# Altium schematic sheet Main_BLE.SchDoc
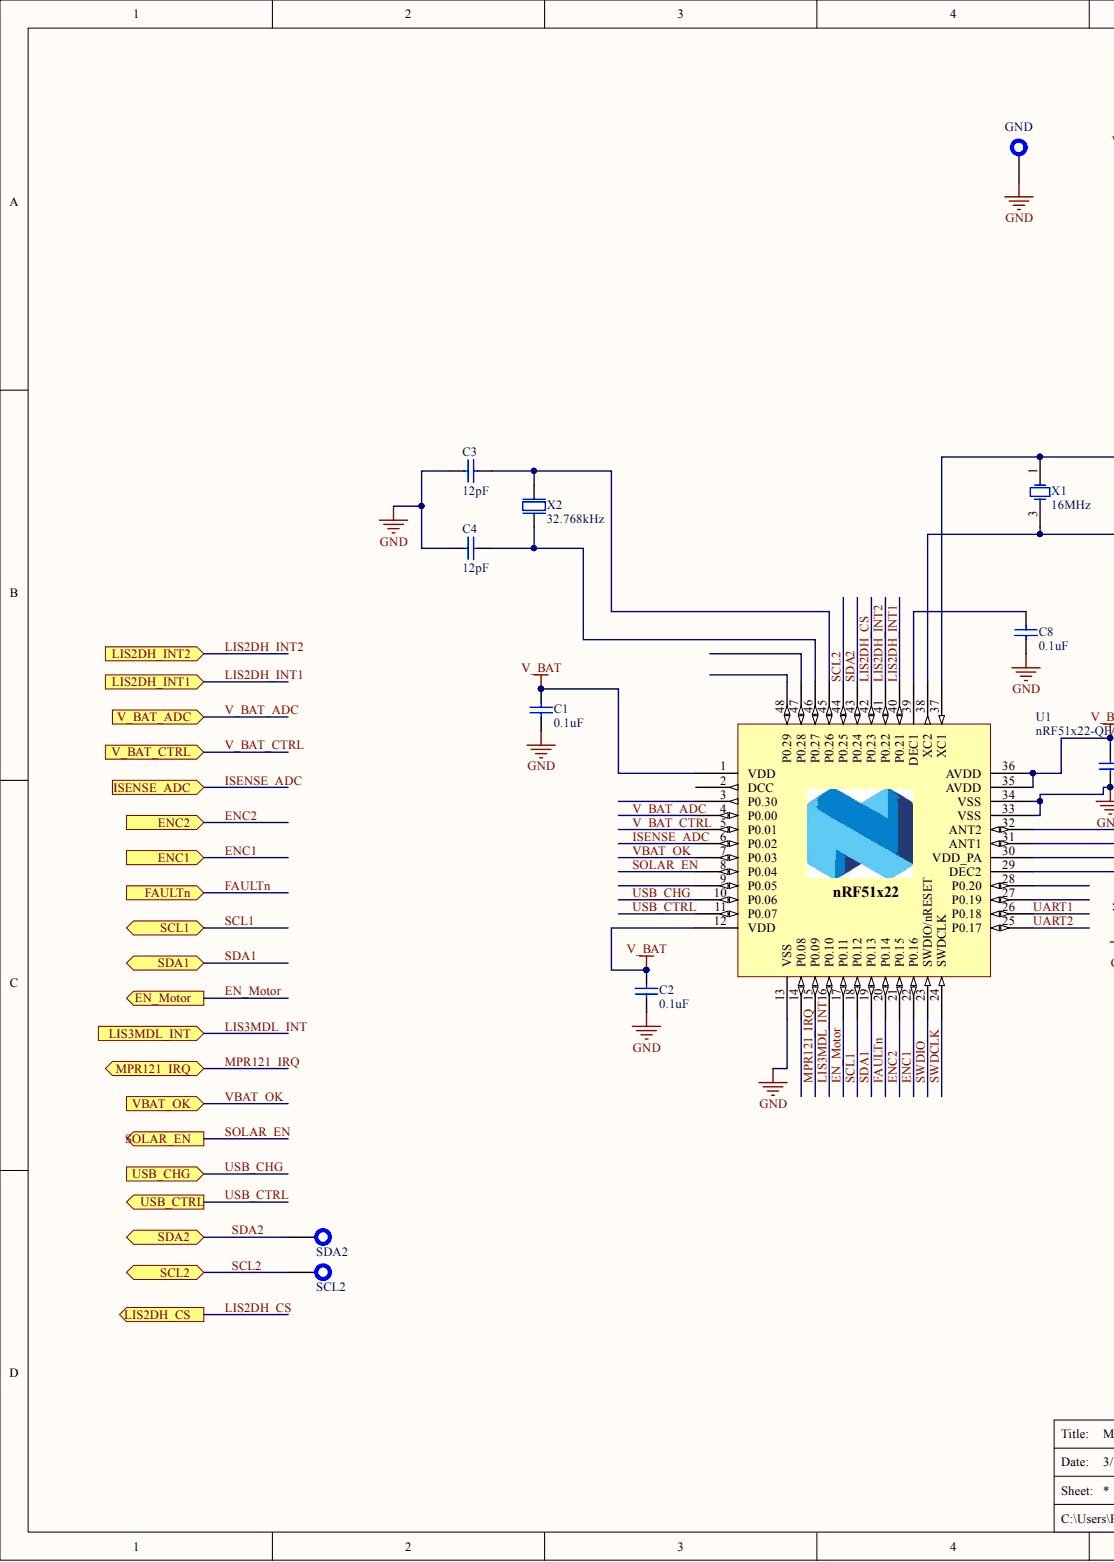
[diagram p0: Main_BLE.SchDoc, left]
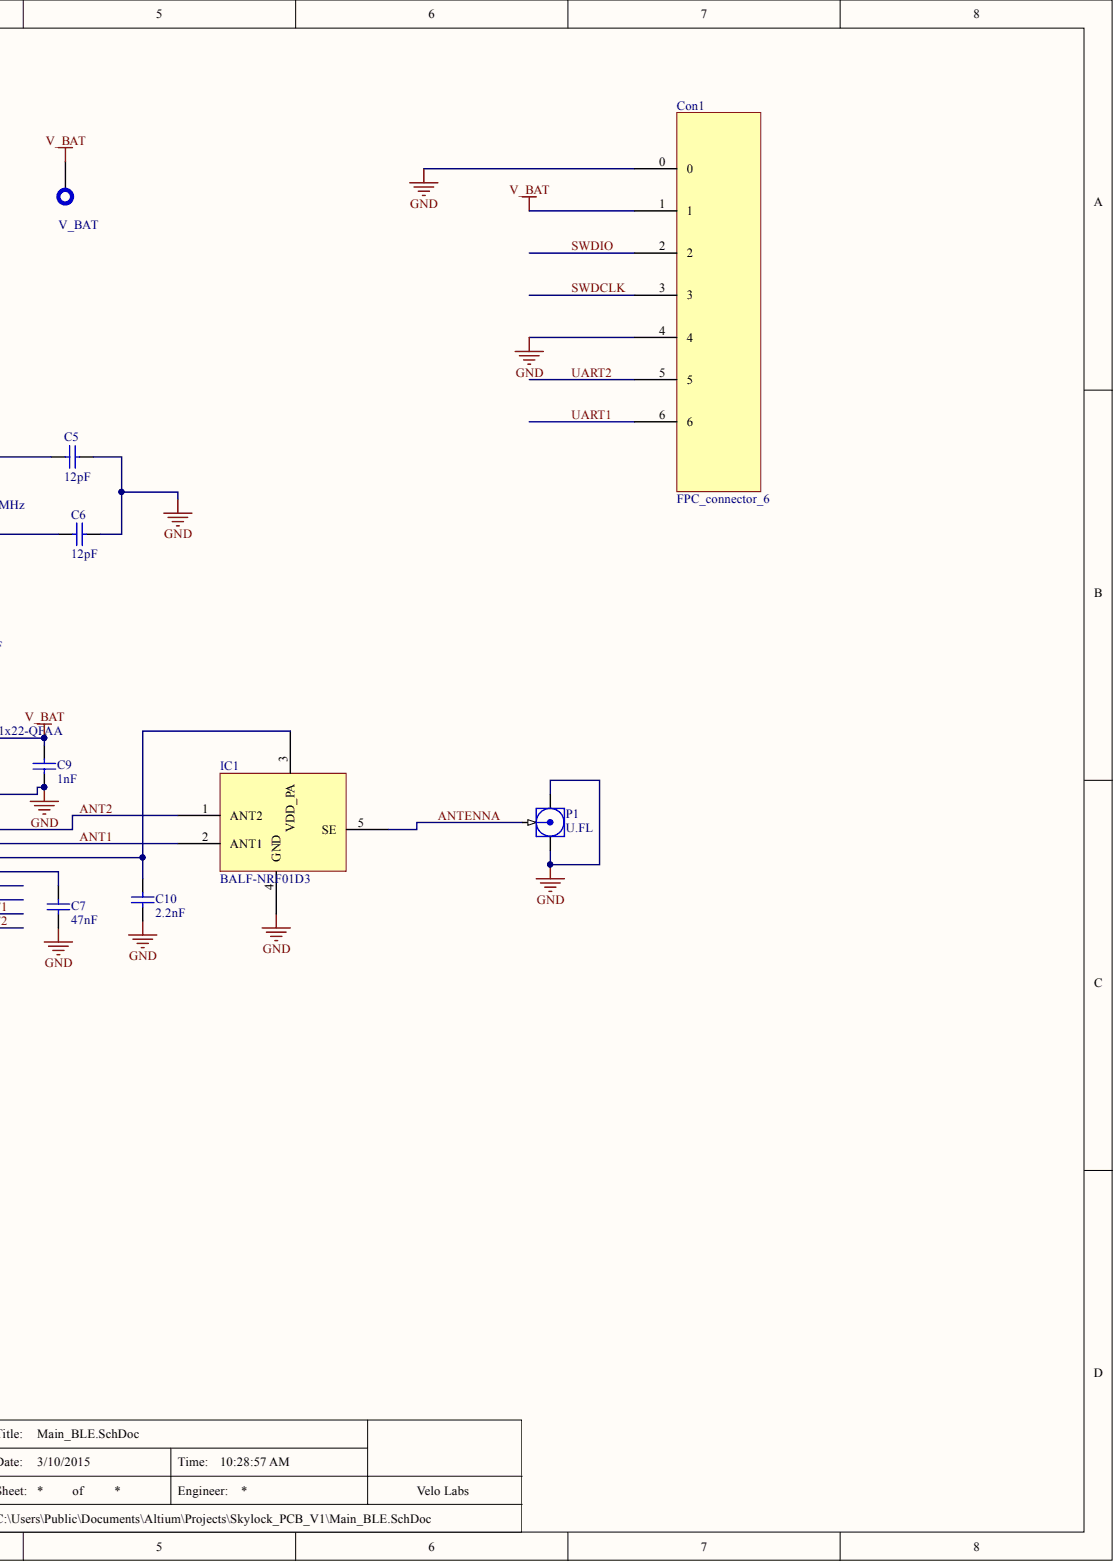
[diagram p1: Main_BLE.SchDoc, right]

## Parts
C1  0.1uF  [C0402]
C2  0.1uF  [C0402]
C3  12pF  [C0402]
C4  12pF  [C0402]
C5  12pF  [C0402]
C6  12pF  [C0402]
C7  47nF  [C0402]
C8  0.1uF  [C0402]
C9  1nF  [C0402]
C10  2.2nF  [C0402]
Con1  FPC_connector_6  [FPC_6Ckt_0.5]
GND  Test Point  [TP]  (value not drawn)  (no BOM)
IC1  BALF-NRF01D3  [5-WFBGA]
P1  U.FL  [U.FL-M]
SCL2  Test Point  [TP]  (value not drawn)  (no BOM)
SDA2  Test Point  [TP]  (value not drawn)  (no BOM)
U1  nRF51x22-QFAA  [QFN40P600X600X90-48N]
V_BAT  Test Point  [TP]  (value not drawn)  (no BOM)
X1  16MHz  [BT-XTAL_2520]
X2  32.768kHz  [XTAL_3215]

## Ports
EN_MOTOR, ENC1, ENC2, FAULTN, ISENSE_ADC, LIS2DH_CS, LIS2DH_INT1, LIS2DH_INT2, LIS3MDL_INT, MPR121_IRQ, SCL1, SCL2, SDA1, SDA2, SOLAR_EN, USB_CHG, USB_CTRL, V_BAT_ADC, V_BAT_CTRL, VBAT_OK

## Nets
GND: C1.2 C2.2 C3.1 C4.1 C5.2 C6.2 C7.2 C8.2 C9.2 C10.2 Con1.0 Con1.4 GND.1 IC1.4(GND) P1.2 P1.3 U1.13(VSS) U1.33(VSS) U1.34(VSS) U1.49 X1.2 X1.4
V_BAT: C1.1 C2.1 C9.1 Con1.1 U1.1(VDD) U1.12(VDD) U1.35(AVDD) U1.36(AVDD) V_BAT.1
ANT1: IC1.2(ANT1) U1.31(ANT1)
ANT2: IC1.1(ANT2) U1.32(ANT2)
ANTENNA: IC1.5(SE) P1.1
EN_MOTOR: U1.17(P0.11) ; port EN_MOTOR
ENC1: U1.22(P0.16) ; port ENC1
ENC2: U1.21(P0.15) ; port ENC2
FAULTN: U1.20(P0.14) ; port FAULTN
ISENSE_ADC: U1.6(P0.02) ; port ISENSE_ADC
LIS2DH_CS: U1.42(P0.23) ; port LIS2DH_CS
LIS2DH_INT1: U1.40(P0.21) ; port LIS2DH_INT1
LIS2DH_INT2: U1.41(P0.22) ; port LIS2DH_INT2
LIS3MDL_INT: U1.16(P0.10) ; port LIS3MDL_INT
MPR121_IRQ: U1.15(P0.09) ; port MPR121_IRQ
SCL1: U1.18(P0.12) ; port SCL1
SCL2: SCL2.1 U1.44(P0.25) ; port SCL2
SDA1: U1.19(P0.13) ; port SDA1
SDA2: SDA2.1 U1.43(P0.24) ; port SDA2
SOLAR_EN: U1.8(P0.04) ; port SOLAR_EN
SWDCLK: Con1.3 U1.24(SWDCLK)
SWDIO: Con1.2 U1.23(SWDIO/nRESET)
UART1: Con1.6 U1.26(P0.18)
UART2: Con1.5 U1.25(P0.17)
USB_CHG: U1.10(P0.06) ; port USB_CHG
USB_CTRL: U1.11(P0.07) ; port USB_CTRL
V_BAT_ADC: U1.4(P0.00) ; port V_BAT_ADC
V_BAT_CTRL: U1.5(P0.01) ; port V_BAT_CTRL
VBAT_OK: U1.7(P0.03) ; port VBAT_OK
NetC3_2: C3.2 U1.45(P0.26) X2.1
NetC4_2: C4.2 U1.46(P0.27) X2.2
NetC5_1: C5.1 U1.37(XC1) X1.1
NetC6_1: C6.1 U1.38(XC2) X1.3
NetC7_1: C7.1 U1.29(DEC2)
NetC8_1: C8.1 U1.39(DEC1)
NetC10_1: C10.1 IC1.3(VDD_PA) U1.30(VDD_PA)

Not connected: U1 2(DCC) 3(P0.30) 9(P0.05) 14(P0.08) 27(P0.19) 28(P0.20) 47(P0.28) 48(P0.29)

## Library data of the parts
C1 C2 C8 = Cap Semi: Capacitor; Manufacturer: Murata Electronics North America; Manufacturer Part Number: GRM155R61A104KA01D; Capacitance: 0.1µF; Temperature Coefficient: X5R; Tolerance: ±10%; Voltage - Rated: 10V; Applications: General Purpose; Category: Capacitors; Component Kind: Standard; ComponentLink1Description: http://www.murata.com/~/media/webrenewal/support/library/catalog/products/capacitor/mlcc/c02e.ashx; ComponentLink1URL: http://www.murata.com/~/media/webrenewal/support/library/catalog/products/capacitor/mlcc/c02e.ashx; Features: -; Height - Seated (Max): -; LatestRevisionDate: 29-May-2009; LatestRevisionNote: IPC-7351 Footprint Added.; Lead Spacing: -; Lead Style: -; Mounting Type: Surface Mount, MLCC; Operating Temperature: -55°C ~ 85°C; Package / Case: 0402 (1005 Metric); PackageDescription: Chip Capacitor; PackageReference: C1206; Published: 8-Jun-2000; Ratings: -; RoHS: RoHS Compliant; Signal Integrity: Cap Semi; Simulation: CAP; Size / Dimension: 0.039" L x 0.020" W (1.00mm x 0.50mm); Supplier 1: Digi-Key; Thickness (Max): 0.022" (0.55mm); Value: 0.1uF; Library Name: VL_Skylock_Nordic.SCHLIB; Publisher: Altium Limited
  C1: Packaging: Tape & Reel (TR); Pricing 1: 10000=0.0021, 20000=0.002, 30000=0.0019, 50000=0.0017, 100000=0.00138 (USD); Stock 1: 8020000; Supplier Part Number 1: 490-1318-2-ND
  C2 C8: Packaging: Cut Tape (CT); Pricing 1: 1=0.1, 10=0.012, 50=0.0066, 100=0.0055, 250=0.00452, 500=0.00386, 1000=0.003, 5000=0.0025 (USD); Stock 1: 7995514; Supplier Part Number 1: 490-1318-1-ND
C3-C6 = Cap Semi: Capacitor; Manufacturer: Murata Electronics North America; Manufacturer Part Number: GRM1555C1H120FA01D; Temperature Coefficient: C0G, NP0; Tolerance: ±1%; Voltage - Rated: 50V; Applications: General Purpose; Capacitance: 12pF; Category: Capacitors; Component Kind: Standard; ComponentLink1Description: http://www.murata.com/~/media/webrenewal/support/library/catalog/products/capacitor/mlcc/c02e.ashx; ComponentLink1URL: http://www.murata.com/~/media/webrenewal/support/library/catalog/products/capacitor/mlcc/c02e.ashx; Features: -; Height - Seated (Max): -; LatestRevisionDate: 29-May-2009; LatestRevisionNote: IPC-7351 Footprint Added.; Lead Spacing: -; Lead Style: -; Mounting Type: Surface Mount, MLCC; Operating Temperature: -55°C ~ 125°C; Package / Case: 0402 (1005 Metric); PackageDescription: Chip Capacitor; PackageReference: C1206; Packaging: Cut Tape (CT); Pricing 1: 1=0.1, 10=0.054, 50=0.0292, 100=0.0247, 250=0.0202, 500=0.01728, 1000=0.0132, 5000=0.011 (USD); Published: 8-Jun-2000; Ratings: -; RoHS: RoHS Compliant; Signal Integrity: Cap Semi; Simulation: CAP; Size / Dimension: 0.039" L x 0.020" W (1.00mm x 0.50mm); Stock 1: 295513; Supplier 1: Digi-Key; Supplier Part Number 1: 490-6196-1-ND; Thickness (Max): 0.022" (0.55mm); Value: 12pF; Library Name: VL_Skylock_Nordic.SCHLIB; Publisher: Altium Limited
C7 = Cap Semi: Capacitor (Semiconductor SIM Model); Manufacturer: Murata Electronics North America; Manufacturer Part Number: GRM155R71E473KA88D; Capacitance: 0.047µF; Temperature Coefficient: X7R; Tolerance: ±10%; Voltage - Rated: 25V; Applications: General Purpose; Category: Capacitors; Component Kind: Standard; ComponentLink1Description: http://www.murata.com/~/media/webrenewal/support/library/catalog/products/capacitor/mlcc/c02e.ashx; ComponentLink1URL: http://www.murata.com/~/media/webrenewal/support/library/catalog/products/capacitor/mlcc/c02e.ashx; Features: -; Height - Seated (Max): -; LatestRevisionDate: 29-May-2009; LatestRevisionNote: IPC-7351 Footprint Added.; Lead Spacing: -; Lead Style: -; Mounting Type: Surface Mount, MLCC; Operating Temperature: -55°C ~ 125°C; Package / Case: 0402 (1005 Metric); PackageDescription: Chip Capacitor; PackageReference: C1206; Packaging: Cut Tape (CT); Pricing 1: 1=0.1, 10=0.029, 50=0.0158, 100=0.0134, 250=0.01092, 500=0.00936, 1000=0.00729, 5000=0.00608 (USD); Published: 8-Jun-2000; Ratings: -; RoHS: RoHS Compliant; Signal Integrity: Cap Semi; Simulation: CAP; Size / Dimension: 0.039" L x 0.020" W (1.00mm x 0.50mm); Stock 1: 454632; Supplier 1: Digi-Key; Supplier Part Number 1: 490-3254-1-ND; Thickness (Max): 0.022" (0.55mm); Value: 47nF; Library Name: VL_Skylock_Nordic.SCHLIB; Publisher: Altium Limited
C9 = Cap Semi: Capacitor (Semiconductor SIM Model); Manufacturer: Murata Electronics North America; Manufacturer Part Number: GRM1555C1H102FA01D; Capacitance: 1000pF; Temperature Coefficient: C0G, NP0; Tolerance: ±1%; Voltage - Rated: 50V; Applications: General Purpose; Category: Capacitors; Component Kind: Standard; ComponentLink1Description: http://www.murata.com/~/media/webrenewal/support/library/catalog/products/capacitor/mlcc/c02e.ashx; ComponentLink1URL: http://www.murata.com/~/media/webrenewal/support/library/catalog/products/capacitor/mlcc/c02e.ashx; Features: -; Height - Seated (Max): -; LatestRevisionDate: 29-May-2009; LatestRevisionNote: IPC-7351 Footprint Added.; Lead Spacing: -; Lead Style: -; Mounting Type: Surface Mount, MLCC; Operating Temperature: -55°C ~ 125°C; Package / Case: 0402 (1005 Metric); PackageDescription: Chip Capacitor; PackageReference: C1206; Packaging: Cut Tape (CT); Pricing 1: 1=0.22, 10=0.147, 50=0.089, 100=0.0748, 250=0.06052, 500=0.0534, 1000=0.04539, 5000=0.03827 (USD); Published: 8-Jun-2000; Ratings: -; RoHS: RoHS Compliant; Signal Integrity: Cap Semi; Simulation: CAP; Size / Dimension: 0.039" L x 0.020" W (1.00mm x 0.50mm); Stock 1: 119743; Supplier 1: Digi-Key; Supplier Part Number 1: 490-6189-1-ND; Thickness (Max): 0.022" (0.55mm); Value: 1nF; Library Name: VL_Skylock_Nordic.SCHLIB; Publisher: Altium Limited
C10 = Cap Semi: Capacitor (Semiconductor SIM Model); Manufacturer: Murata Electronics North America; Manufacturer Part Number: GRM155R71H222KA01D; Capacitance: 2200pF; Temperature Coefficient: X7R; Tolerance: ±10%; Voltage - Rated: 50V; Applications: General Purpose; Category: Capacitors; Component Kind: Standard; ComponentLink1Description: http://www.murata.com/~/media/webrenewal/support/library/catalog/products/capacitor/mlcc/c02e.ashx; ComponentLink1URL: http://www.murata.com/~/media/webrenewal/support/library/catalog/products/capacitor/mlcc/c02e.ashx; Features: -; Height - Seated (Max): -; LatestRevisionDate: 29-May-2009; LatestRevisionNote: IPC-7351 Footprint Added.; Lead Spacing: -; Lead Style: -; Mounting Type: Surface Mount, MLCC; Operating Temperature: -55°C ~ 125°C; Package / Case: 0402 (1005 Metric); PackageDescription: Chip Capacitor; PackageReference: C1206; Packaging: Cut Tape (CT); Pricing 1: 1=0.1, 10=0.016, 50=0.0086, 100=0.0072, 250=0.00588, 500=0.00504, 1000=0.00393, 5000=0.00328 (USD); Published: 8-Jun-2000; Ratings: -; RoHS: RoHS Compliant; Signal Integrity: Cap Semi; Simulation: CAP; Size / Dimension: 0.039" L x 0.020" W (1.00mm x 0.50mm); Stock 1: 460656; Supplier 1: Digi-Key; Supplier Part Number 1: 490-1305-1-ND; Thickness (Max): 0.022" (0.55mm); Value: 2.2nF; Library Name: VL_Skylock_Nordic.SCHLIB; Publisher: Altium Limited
Con1 = FPC_connector_6: MOLEX FPC non ZIF 6Ckt 0.5mm pitch; Manufacturer Part Number: 51281-0694; Current Rating: 500 mA; Mounting Angle: Right; Number of Positions: 6; Voltage Rating: 50 V; Brand: Molex; Category: FFC & FPC Connectors; Component Kind: Standard; ComponentLink1Description: http://www.mouser.com/ds/2/276/0512810694_FFC_FPC_CONNECTORS-170379.pdf; ComponentLink1URL: http://www.mouser.com/ds/2/276/0512810694_FFC_FPC_CONNECTORS-170379.pdf; Contact Material: Phosphor Bronze; Contact Plating: Gold; Housing Material: Thermoplastic; Manufacturer: Molex; Minimum Order Quantity: 1; Packaging: Reel; Pitch: 0.5 mm; Pricing 1: 1=0.950, 10=0.937, 100=0.930, 500=0.922, 1000=0.898, 2000=0.885, 3000=0.790, 9000=0.751, 24000=0.719 (AUD); Product Category: .50mm FFC/FPC Connectors; Product Type: Board Mount; Reeling: True; RoHS: RoHS Compliant; RoHS - Mouser: Y; Series: 51281; Standard Pack Qty: 3000; Stock 1: 3658; Supplier 1: Mouser; Supplier Part Number 1: 538-51281-0694; Termination Style: SMD; Library Name: VL_Skylock_Nordic.SCHLIB
IC1 = BALF-NRF01D3: ST_Balun_Nordic; Manufacturer: STMicroelectronics; Manufacturer Part Number: BAL-NRF01D3; Frequency Range: 2.4GHz ~ 2.54GHz; Impedance - Unbalanced/Balanced: 50 / - Ohm; Insertion Loss (Max): 2.25dB; Phase Difference: 3°; Return Loss (Min): 10dB; Category: RF/IF and RFID; Component Kind: Standard; ComponentLink1Description: http://www.st.com/st-web-ui/static/active/en/resource/technical/document/datasheet/DM00055606.pdf; ComponentLink1URL: http://www.st.com/st-web-ui/static/active/en/resource/technical/document/datasheet/DM00055606.pdf; Mounting Type: Surface Mount; Package / Case: 5-WFBGA, FCBGA; Packaging: Cut Tape (CT); Pricing 1: 1=0.84, 10=0.736, 25=0.6508, 100=0.5671, 250=0.49368, 500=0.42024, 1000=0.33 (USD); RoHS: RoHS Compliant; Stock 1: 17419; Supplier 1: Digi-Key; Supplier Part Number 1: 497-13637-1-ND; Library Name: VL_Skylock_Nordic.SCHLIB
P1 = U.FL: CONN UMC JACK STR 50 OHM SMD; Manufacturer: Hirose Electric Co Ltd; Manufacturer Part Number: U.FL-R-SMT(10); Body Finish: Silver; Body Material: Phosphor Bronze; Center Contact Plating: Gold; Connector Style: Ultra Miniature Coaxial; Connector Type: Jack, Male Pin; Contact Termination: Solder; Dielectric Material: Liquid Crystal Polymer (LCP); Frequency - Max: 6GHz; Impedance: 50 Ohm; Cable Group: -; Category: Connectors, Interconnects; Center Contact Material: Phosphor Bronze; Component Kind: Standard; ComponentLink1Description: http://www.hirose.co.jp/cataloge_hp/e32119372.pdf; ComponentLink1URL: http://www.hirose.co.jp/cataloge_hp/e32119372.pdf; Description 1: CONN UMC JACK STR 50 OHM SMD; Fastening Type: Snap-On; Features: -; Housing Color: Silver; Ingress Protection: -; Mounting Type: Surface Mount; Operating Temperature: -40°C ~ 90°C; Packaging: Cut Tape (CT); Pricing 1: 1=1.31, 10=1.15, 25=1.0824, 50=1.0374, 100=0.9922, 250=0.902, 500=0.8118, 1000=0.7216 (USD); RoHS: RoHS Compliant; Shield Termination: Solder; Stock 1: 135430; Supplier 1: Digi-Key; Supplier Part Number 1: H9161CT-ND; Voltage Rating: -; Library Name: VL_Skylock_Nordic.SCHLIB
U1 = (no symbol name): Multi-protocol Bluetooth Low Energy, ANT and 2.4GHz proprietary system-on-chip; Manufacturer: Nordic Semiconductor; Artikkelnr Noca: N.A.; Note: nRF51422 - QFN48 6x6 0.4mm pitch; Artikkelnr Simpro: N.A.; Component Kind: Standard; Footprint Doc: QFN-48; LatestRevisionDate: 2014-09-24; Manufacturer Part Number: nRF51x22-QFAA; Part Number: nRF51x22-QFAA; Pin Count: 48; Published: 2014-09-24; Supplier: Nordic Semiconductor; Supplier 1: Digi-Key; Supplier Part Number 1: 1490-1031-1-ND; Value: nRF51x22-QFAA; Library Name: VL_Skylock_Nordic.SCHLIB; Publisher: Nordic Semiconductor
X1 = NX2520SA-16MHZ-EXS00A-CS05116: CRYSTAL 16MHZ 8PF SMD; Manufacturer: NDK; Description 1: CRYSTAL 16MHZ 12PF SMD; ESR (Equivalent Series Resistance): 80 Ohm; Frequency Stability: ±15ppm; Load Capacitance: 12pF; Operating Mode: Fundamental; Category: Crystals and Oscillators; Component Kind: Standard; ComponentLink1Description: http://media.digikey.com/pdf/Data%20Sheets/NDK%20PDFs/NX2520SA-16MHZ-EXS00A-CS05116.pdf; ComponentLink1URL: http://media.digikey.com/pdf/Data%20Sheets/NDK%20PDFs/NX2520SA-16MHZ-EXS00A-CS05116.pdf; Frequency: 16MHz; Frequency Tolerance: ±15ppm; Height: 0.022" (0.55mm); Manufacturer Part Number: NX2520SA-16MHZ-EXS00A-CS05116; Mounting Type: Surface Mount; Operating Temperature: -10°C ~ 75°C; Package / Case: 4-SMD, No Lead (DFN, LCC); Packaging: Cut Tape (CT); Pricing 1: 1=1.45, 10=1.384, 50=1.3534, 100=1.1574, 500=1.12674, 1000=0.94916 (USD); Ratings: -; RoHS: RoHS Compliant; Size / Dimension: 0.098" L x 0.079" W (2.50mm x 2.00mm); Stock 1: 3775; Supplier 1: Digi-Key; Supplier Part Number 1: 644-1200-1-ND; Type: MHz Crystal; Value: 16MHz; Library Name: VL_Skylock_Nordic.SCHLIB
X2 = (no symbol name): XTAL SMD 3215, 32.768kHz, 9pF, ±20ppm; 2nd source part number: 127-8037; Manufacturer: Epson Toyocom; Manufacturer Part Number: FC-135 32.768KHZ ±20PPM,9.0PF; Part Number: XTAL_FC-135 32.768k; Artikkelnr Noca: 22019091; Artikkelnr Simpro: N.A.; Note: XTAL SMD 32.768kHz, 3215; 2nd source Supplier: Farnell; Component Kind: Standard; Datasheet: http://www.farnell.com/datasheets/57480.pdf; Footprint Doc: XTAL_3215; LatestRevisionDate: 2014-03-27; Pin Count: 2; Published: 2010-10-18; Supplier: Digi-Key; Supplier part number: FC-135 32.7680KA-AC-ND; Value: 32.768kHz; Library Name: VL_Skylock_Nordic.SCHLIB; Publisher: Nordic Semiconductor
GND SCL2 SDA2 V_BAT = Test Point: Description: Test Point; Library Name: VL_Skylock_Nordic.SCHLIB
